# Altium schematic sheet CS IC.SchDoc
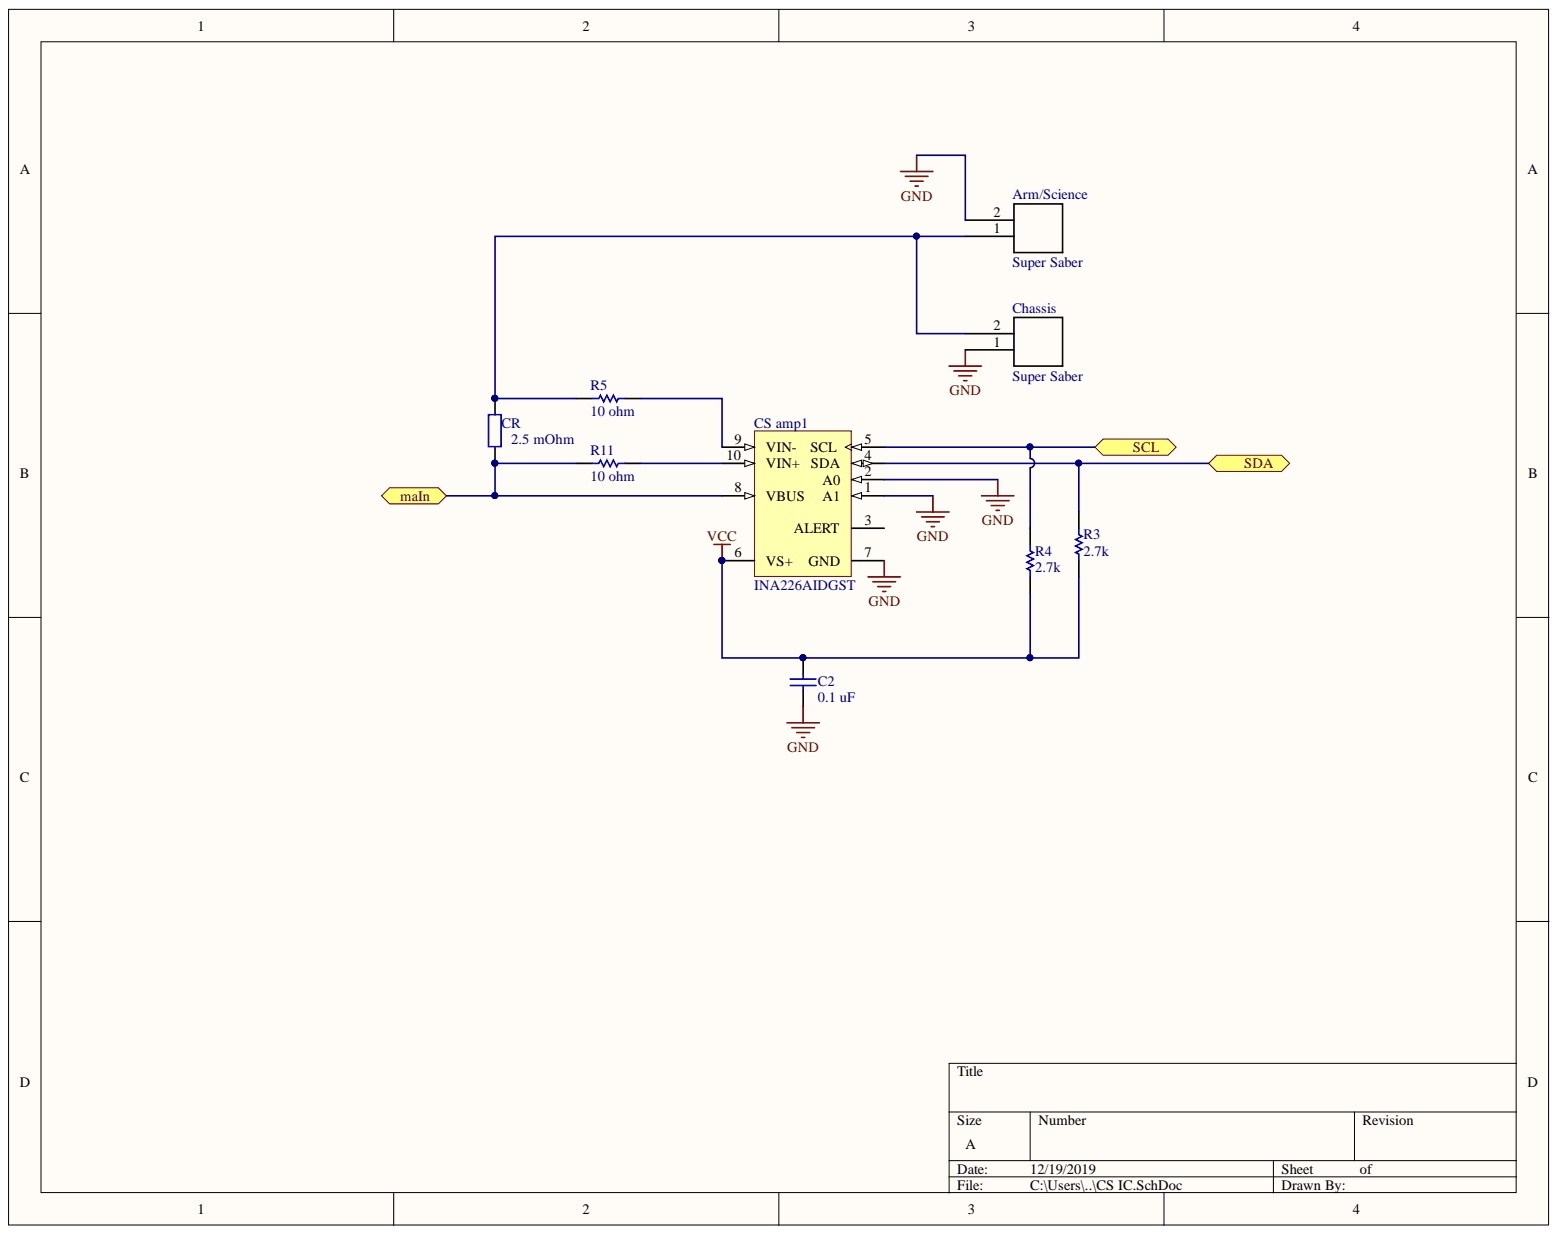
[diagram p0: CS IC.SchDoc]

## Parts
Arm/Science  Super Saber  [PCBComponent_1]
C2  0.1 uF  [CAPC0805(2012)130_N]
Chassis  Super Saber  [PCBComponent_1]
CR  value 2.5 mOhm  [current sens res]
CS_amp1  INA226AIDGST  [DGS0010A_N]
R3  2.7k  [RESC0805(2012)_N]
R4  2.7k  [RESC0805(2012)_N]
R5  10 ohm  [RESC0805(2012)_N]
R11  10 ohm  [RESC0805(2012)_N]

## Ports
maIn, SCL, SDA

## Nets
GND: Arm/Science.2 C2.1 Chassis.1 CS_amp1.1(A1) CS_amp1.2(A0) CS_amp1.7(GND)
VCC: C2.2 CS_amp1.6(VS+) R3.1 R4.1
maIn: CR.1 CS_amp1.8(VBUS) R11.2 ; port maIn
SCL: CS_amp1.5(SCL) R4.2 ; port SCL
SDA: CS_amp1.4(SDA) R3.2 ; port SDA
NetArm/Science_1: Arm/Science.1 Chassis.2 CR.2 R5.2
NetCS amp1_9: CS_amp1.9(VIN-) R5.1
NetCS amp1_10: CS_amp1.10(VIN+) R11.1

Not connected: CS_amp1 3(ALERT)

## Library data of the parts
C2 = CMP-1036-02603-1: CAP 390pF 200V ±5% 0805 (2012 Metric) Thickness 1.3mm SMD; Case-EIA: 0805; Case-Metric: 2012; Tolerance: Â±5%; Component Kind: Standard; Library Name: Altium Content Vault; Max Thickness: 1.3 mm; Rated Voltage: 200 V; Technology: SMT; Value: 390pF
CR = Res2: 2.5 mOhm shunt; Component Kind: Standard; LatestRevisionDate: 17-Jul-2002; LatestRevisionNote: Re-released for DXP Platform.; Library Name: Miscellaneous Devices.IntLib; PackageDescription: Axial Device, Thru-Hole; 2 Leads; 0.4 in Pin Spacing; PackageReference: AXIAL-0.4; Published: 8-Jun-2000; Signal Integrity: Res; Simulation: RESISTOR; Publisher: Altium Limited
CS_amp1 = CMP-0704-00057-2: High-Side Measurement, Bi-Directional Current / Power Monitor with I2C Interface, 2.7 to 5.5 V, -40 to 125 degC, 10-pin SOP (DGS10), Green (RoHS & no Sb/Br); CMRR(Min)(dB): 126; Common Mode Range(Max)(V): 36; Common Mode Range(Min)(V): 0; Gain(V/V): 1; Input Offset (+/-)(Max)(uV): 10; Input Offset Drift (+/-)(Max)(uV/Degrees Celsius): 0.1; Quiescent Current (+/-)(Typ)(mA): 0.33; Rating: Catalog; Small-Signal Bandwidth(Typ)(MHz): 0.007; SubFamily: Current Shunt Monitor; Vs(Max)(V): 5.5; Vs(Min)(V): 2.7; Code_JEDEC: MO-153; Component Kind: Standard; ComponentLink1Description: Manufacturer URL; ComponentLink1URL: http://www.ti.com/; ComponentLink2Description: Datasheet; ComponentLink2URL: http://www.ti.com/general/docs/lit/getliterature.tsp?genericPartNumber=INA226&fileType=pdf; ComponentLink3Description: Package Specification; ComponentLink3URL: http://www.ti.com/lit/ml/mpds035b/mpds035b.pdf; ComponentLink4Description: 830K ohm internal impedance; ComponentLink4URL: http://www.ti.com/lit/ug/tidu849/tidu849.pdf; DatasheetVersion: SBOS547; Eco-Plan: Green (RoHS & no Sb/Br); Library Name: Altium Content Vault; Mounting Technology: Surface Mount; PackageDescription: 10-Pin Plastic Small Outline, Body 3 x 3 mm, Pitch 0.5 mm; PackageReference: DGS0010A; PackageVersion: 4073272/C, 02/2004; PartNumber: INA226AIDGST
R3-R5 R11 = CMP-1013-00418-1: 160R 0.125W 1% 0805 (2012 Metric)  SMD; Case-EIA: 0805; Case-Metric: 2012; Value: 160.00 Ohm; Component Kind: Standard; Library Name: Altium Content Vault; Power: 0.125 W; Technology: SMT; Tolerance: 1 %
  R3 R4: ComponentLink1Description: calc; ComponentLink1URL: http://www.ti.com/lit/an/slva689/slva689.pdf
Arm/Science Chassis = Super Saber: Component Kind: Standard; Library Name: Connector Schematic Symbols.SchLib
